# Altium schematic sheet Leptons_2.SchDoc
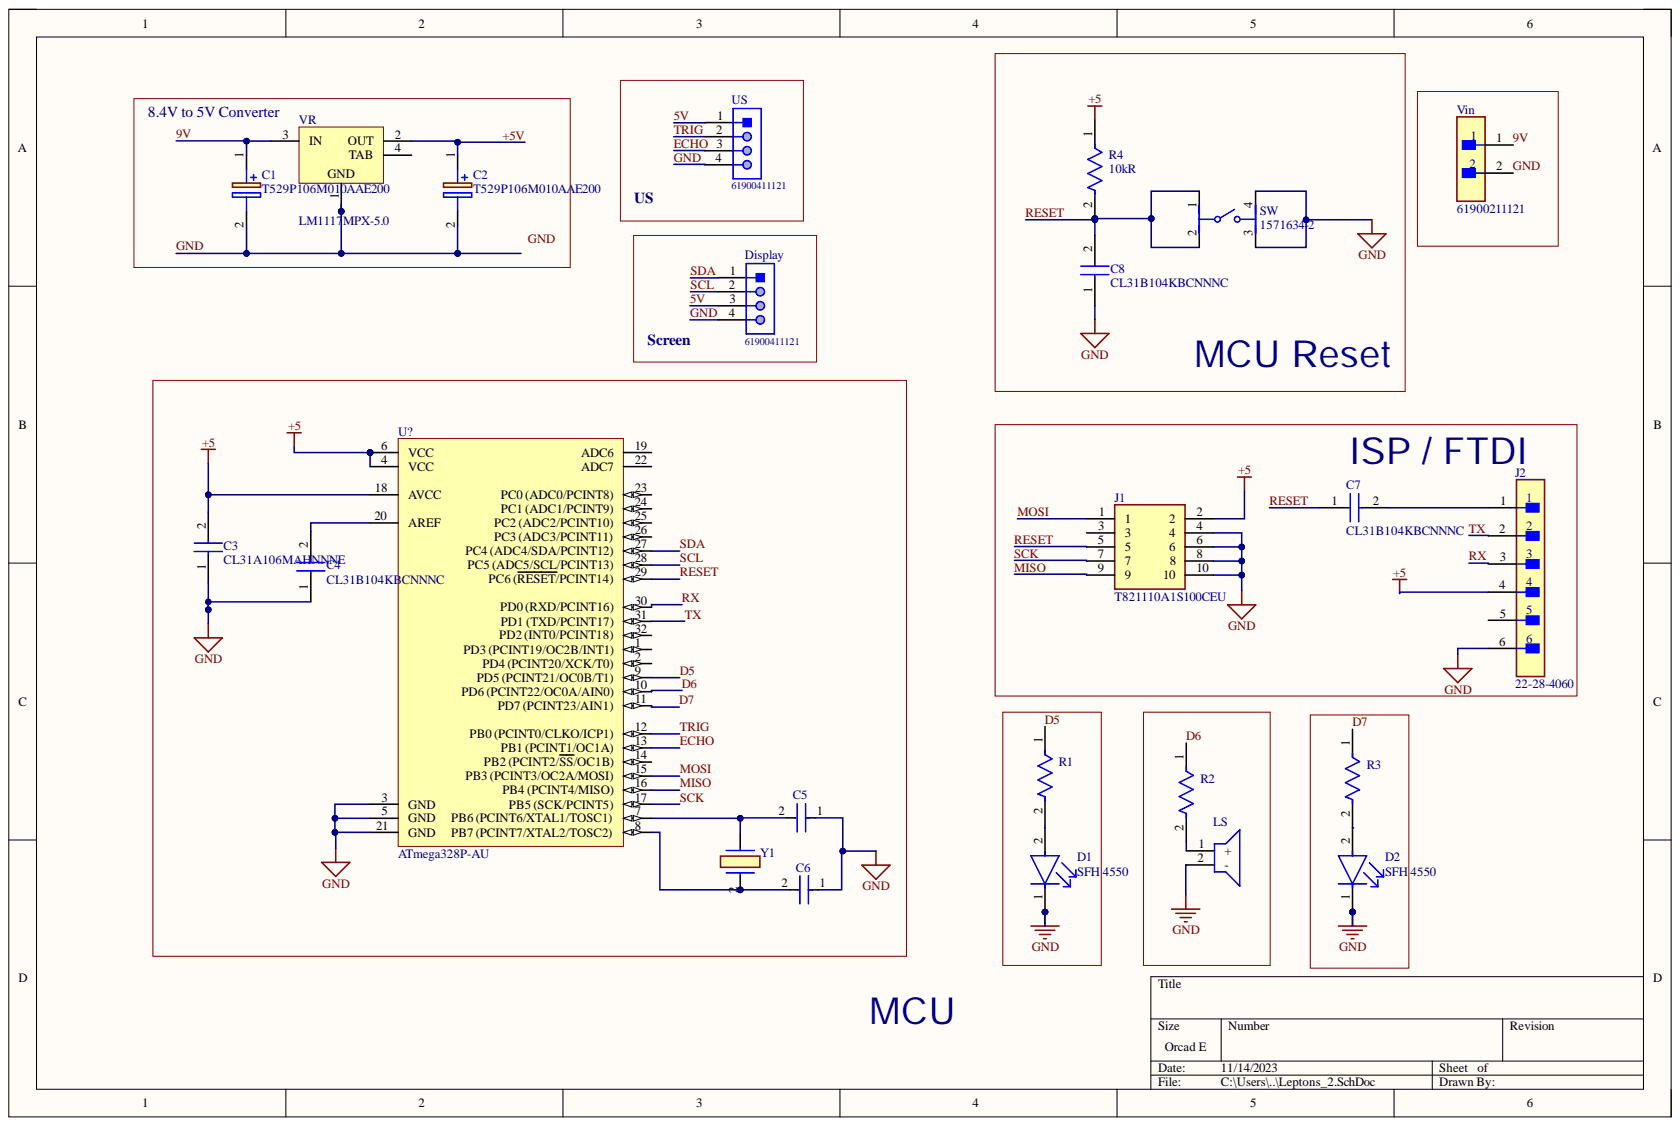
[diagram p0: Leptons_2.SchDoc]

## Parts
C1  T529P106M010AAE200  [T529P]
C2  T529P106M010AAE200  [T529P]
C3  CL31A106MAHNNNE  [CAPC3216X180N]
C4  CL31B104KBCNNNC  [CAPC3216X100N]
C5  CL31C220JBCNNNC  [FP-CL31-IPC_A]  (value not drawn)
C6  CL31C220JBCNNNC  [FP-CL31-IPC_A]  (value not drawn)
C7  CL31B104KBCNNNC  [CAPC3216X100N]
C8  CL31B104KBCNNNC  [CAPC3216X100N]
D1  SFH 4550  [FP-SFH_4550-MFG]
D2  SFH 4550  [FP-SFH_4550-MFG]
Display  61900411121  [61900411121]
J1  T821110A1S100CEU  [SHDR10W64P254X254_2X5_2032X895X915P]
J2  22-28-4060  [FP-22-28-4060-MFG]
LS  CMI-1295-0585T  [CMI12950585T]  (value not drawn)
R1  RMCF1206FT10K0  [FP-RMCF1206-IPC_B]  (value not drawn)
R2  RMCF1206FT10K0  [FP-RMCF1206-IPC_B]  (value not drawn)
R3  RMCF1206FT10K0  [FP-RMCF1206-IPC_B]  (value not drawn)
R4  Resistance: 10kR  [FP-RMCF1206-IPC_B]  (hidden comment: RMCF1206FT10K0)
SW  1571634-2  [FP-1571634-2-MFG]
U?  ATmega328P-AU  [32A_M]
US  61900411121  [61900411121]
Vin  61900211121  [FP-61900211121-MFG]
VR  LM1117MPX-5.0  [DCY0004A_L]
Y1  ECS-160-20-3X-TR  [ECS160203XTR]  (value not drawn)

## Nets
5V: C2.1 C3.2 Display.3 J1.2 J2.4 R4.1 U?.4(VCC) U?.6(VCC) U?.18(AVCC) US.1 VR.2(OUT)  [also labelled +5V]
9V: C1.1 Vin.1 VR.3(IN)
D5: R1.1 U?.9(PD5_(PCINT21/OC0B/T1))
D6: R2.1 U?.10(PD6_(PCINT22/OC0A/AIN0))
D7: R3.1 U?.11(PD7_(PCINT23/AIN1))
ECHO: U?.13(PB1_(PCINT1/OC1A)) US.3
GND: C1.2 C2.2 C3.1 C4.1 C5.1 C6.1 C8.1 D1.1 D2.1 Display.4 J1.4 J1.6 J1.8 J1.10 J2.6 LS.2 SW.3 SW.4 U?.3(GND) U?.5(GND) U?.21(GND) US.4 Vin.2 VR.1
MISO: J1.9 U?.16(PB4_(PCINT4/MISO))
MOSI: J1.1 U?.15(PB3_(PCINT3/OC2A/MOSI))
RESET: C7.1 C8.2 J1.5 R4.2 SW.1 SW.2 U?.29(PC6_(RESET/PCINT14))
RX: J2.3 U?.30(PD0_(RXD/PCINT16))
SCK: J1.7 U?.17(PB5_(SCK/PCINT5))
SCL: Display.2 U?.28(PC5_(ADC5/SCL/PCINT13))
SDA: Display.1 U?.27(PC4_(ADC4/SDA/PCINT12))
TRIG: U?.12(PB0_(PCINT0/CLKO/ICP1)) US.2
TX: J2.2 U?.31(PD1_(TXD/PCINT17))
NetC4_2: C4.2 U?.20(AREF)
NetC5_2: C5.2 U?.7 Y1.
NetC6_2: C6.2 U?.8 Y1.2
NetC7_2: C7.2 J2.1
NetD1_2: D1.2 R1.2
NetD2_2: D2.2 R3.2
NetLS_1: LS.1 R2.2

Not connected: J1 3; J2 5; U? 1(PD3_(PCINT19/OC2B/INT1)) 2(PD4_(PCINT20/XCK/T0)) 14(PB2_(PCINT2/SS/OC1B)) 19(ADC6) 22(ADC7) 23(PC0_(ADC0/PCINT8)) 24(PC1_(ADC1/PCINT9)) 25 26(PC3_(ADC3/PCINT11)) 32(PD2_(INT0/PCINT18)); VR 4(TAB)

## Library data of the parts
C1 C2 = T529P106M010AAE200: Capacitor Polarised; Manufacturer_Name: KEMET; Arrow Part Number: T529P106M010AAE200; Arrow Price/Stock: https://www.arrow.com/en/products/t529p106m010aae200/kemet-corporation?region=nac; Component Kind: Standard; Datasheet Link: https://content.kemet.com/datasheets/KEM_T2076_T52X-530.pdf; Height: 1mm; Manufacturer_Part_Number: T529P106M010AAE200; Mouser Part Number: 80-T529P106M10AAE200; Mouser Price/Stock: https://www.mouser.co.uk/ProductDetail/KEMET/T529P106M010AAE200?qs=sSYV1F9c5cHTMSIZ4fl60g%3D%3D
C3 = CL31A106MAHNNNE: Capacitor; Manufacturer_Name: SAMSUNG; Arrow Part Number: CL31A106MAHNNNE; Arrow Price/Stock: https://www.arrow.com/en/products/cl31a106mahnnne/samsung-electro-mechanics?region=nac; Component Kind: Standard; Datasheet Link: https://www.arrow.com/en/products/cl31a106mahnnne/samsung-electro-mechanics; Height: 1.8mm; Manufacturer_Part_Number: CL31A106MAHNNNE; Mouser Part Number: 187-CL31A106MAHNNNE; Mouser Price/Stock: https://www.mouser.co.uk/ProductDetail/Samsung-Electro-Mechanics/CL31A106MAHNNNE?qs=9y2YoPkPyvYkOWbcUKSn%252BQ%3D%3D
C4 C7 C8 = CL31B104KBCNNNC: Capacitor; Manufacturer_Name: SAMSUNG; Arrow Part Number: CL31B104KBCNNNC; Arrow Price/Stock: https://www.arrow.com/en/products/cl31b104kbcnnnc/samsung-electro-mechanics?region=nac; Component Kind: Standard; Datasheet Link: https://datasheet.datasheetarchive.com/originals/distributors/Datasheets_SAMA/0fdb6a11e020a7299f4d0713dd2bd7ee.pdf; Height: 1mm; Manufacturer_Part_Number: CL31B104KBCNNNC; Mouser Part Number: 187-CL31B104KBCNNNC; Mouser Price/Stock: https://www.mouser.co.uk/ProductDetail/Samsung-Electro-Mechanics/CL31B104KBCNNNC?qs=349EhDEZ59oHABbyGq1iKA%3D%3D
C5 C6 = CMP-13271-001055-1: Cap Ceramic 22pF 50V C0G ±5% SMD 1206 +125°C Embossed T/R; Temperature Coefficient: 300ppm/°C; Capacitance: 22pF; Case Code (Imperial): 1206; Case Code (Metric): 3216; Case/Package: 1206; Component Kind: Standard; Depth: 1.6mm; Dielectric: C0G; Height: 0.85mm; Lead Free: Lead Free; Length: 3.2mm; Library Name: Altium Content Vault; Material: Ceramic; Max Operating Temperature: 125°C; Min Operating Temperature: -55°C; Mount: Surface Mount; Package Quantity: 1; Packaging: Tape and Reel; Radiation Hardening: No; Thickness: 0.039inch; Tolerance: 5%; Voltage: 50V; Voltage Rating: 50V; Voltage Rating (DC): 50V; Width: 0.063inch
D1 D2 = CMP-62016-000011-1: EMITTER IR 860NM 100MA RADIAL; Manufacturer: Osram Opto; Colour: Infrared; Forward Voltage: 1.5V; Led Orientation: Straight; Reverse Voltage: 5V; Viewing Angle: 6°; Automotive: No; Category: Diode; Component Kind: Standard; ComponentLink2Description: Datasheet; ComponentLink2URL: https://dammedia.osram.info/media/resource/hires/osram-dam-5580407/SFH%204550_EN.pdf; Device Class L1: Optoelectronics; Device Class L2: LEDs; Device Class L3: unset; Digikey Description: EMITTER IR 860NM 100MA RADIAL; Forward Current: 100mA; Lead Free: Yes; Library Name: Altium Content Vault; Manufacturer Part Number: SFH 4550; Mouser Description: Infrared Emitters - High Power Infrared 850 nm HALF ANGLE +/-3DEG; Octopart: https://octopart.com/sfh+4550-osram+opto-55410894; Package: SFH 4550; Peak Emmision Wavelength: 860nm; RoHS: TRUE; Temperature: -40°C to +100°C; Temperature Range High: +100°C; Temperature Range Low: -40°C
Display US = CMP-1502-00586-1: Male Locking Header WR-WTB, THT, Vertical, pitch 2.54 mm, 1 x 4 position; Manufacturer: Wurth Elektronik; Contact Resistance: 20mOhm; Pitch: 2.54mm; Position: 1x4; Working Voltage: 250VAC; Component Kind: Standard; Datasheet URL: https://katalog.we-online.de/em/datasheet/6190xx11121.pdf; Gender: Male; Library Name: Altium Content Vault; Manufacturer URL: http://www.we-online.de; Mounting Technology: THT; Operating Temperature: -40to105degC; Orientation: Vertical; Package Reference: 61900411121; Packaging: Bag; Part Number: 61900411121; Publisher: WurthElektronik
J1 = T821110A1S100CEU: Connector; Manufacturer_Name: Amphenol; Arrow Part Number: T821110A1S100CEU; Arrow Price/Stock: https://www.arrow.com/en/products/t821110a1s100ceu/amphenol-fci?region=europe; Component Kind: Standard; Datasheet Link: https://docs.rs-online.com/e09c/0900766b81359cba.pdf; Height: 9.15mm; Manufacturer_Part_Number: T821110A1S100CEU; Mouser Part Number: N/A; Mouser Price/Stock: https://www.mouser.co.uk/ProductDetail/Amphenol/T821110A1S100CEU?qs=3IN%252Br8p6BrNf%2FuKCWm44Bg%3D%3D
J2 = CMP-04776-000763-1: CONN HEADER VERT 6POS 2.54MM; Connector Type: Male Pin; Contact Resistance: 20mR; Current Rating: 4A; Gender: Male; Max Voltage Rating (AC): 250V; Max Voltage Rating (DC): 250.25kV; Number of Contacts: 6; Color: Black; Component Kind: Standard; Contact Material: Brass; Contact Mating Length: 0.24inch; Contact Plating: Tin; Contact Type: Male Pin; Depth: 2.49mm; Housing Color: Black; Housing Material: Thermoplastic; Length: 15.24mm; Library Name: Altium Content Vault; Max Operating Temperature: 75°C; Min Operating Temperature: 0°C; Mount: Through Hole; Number of Positions: 6; Number of Rows: 1; Orientation: Straight; Package Quantity: 1; Packaging: Bulk; Pitch: 2.54mm; RoHS Compliant: Yes; Series: KK® 42375; Termination: Solder; Voltage Rating: 250V
LS = CMI-1295-0585T: Loudspeaker or Buzzer; Manufacturer_Name: CUI Devices; Arrow Part Number: CMI-1295-0585T; Arrow Price/Stock: https://www.arrow.com/en/products/cmi-1295-0585t/cui-devices?region=nac; Component Kind: Standard; Datasheet Link: https://www.cuidevices.com/product/resource/pdf/cmi-1295-0585t.pdf; Height: 9.7mm; Manufacturer_Part_Number: CMI-1295-0585T; Mouser Part Number: 490-CMI-1295-0585T; Mouser Price/Stock: https://www.mouser.co.uk/ProductDetail/CUI-Devices/CMI-1295-0585T?qs=P1JMDcb91o6b8IYPugSq6A%3D%3D
R1-R3 = CMP-26527-000151-1: 10kΩ ±1% 0.25W 1206 Thick Film Chip Resistor AEC-Q200 compliant RMCF1206FT10K0; Max Power Dissipation: 250mW; Resistance: 10kR; Temperature Coefficient: 100ppm/°C; Case Code (Imperial): 1206; Case Code (Metric): 3216; Case/Package: 1206; Component Kind: Standard; Construction: Rectangular; Contact Plating: Tin; Depth: 1.6mm; Features: Automotive AEC-Q200; Height: 0.028inch; Lead Free: Lead Free; Length: 3.2mm; Library Name: Altium Content Vault; Max Operating Temperature: 155°C; Military Standard: Not; Min Operating Temperature: -55°C; Mount: Surface Mount; Number of Terminations: 2; Packaging: Tape and Reel; Power Rating: 250mW; Radiation Hardening: No; Resistor Type: Thick Film; RoHS Compliant: Yes; Series: RMCF; Size Code: 1206; Tolerance: 1%; Width: 0.063inch
R4 = CMP-26527-000151-1: 10kΩ ±1% 0.25W 1206 Thick Film Chip Resistor AEC-Q200 compliant RMCF1206FT10K0; Max Power Dissipation: 250mW; Temperature Coefficient: 100ppm/°C; Case Code (Imperial): 1206; Case Code (Metric): 3216; Case/Package: 1206; Component Kind: Standard; Construction: Rectangular; Contact Plating: Tin; Depth: 1.6mm; Features: Automotive AEC-Q200; Height: 0.028inch; Lead Free: Lead Free; Length: 3.2mm; Library Name: Altium Content Vault; Max Operating Temperature: 155°C; Military Standard: Not; Min Operating Temperature: -55°C; Mount: Surface Mount; Number of Terminations: 2; Packaging: Tape and Reel; Power Rating: 250mW; Radiation Hardening: No; Resistor Type: Thick Film; RoHS Compliant: Yes; Series: RMCF; Size Code: 1206; Tolerance: 1%; Width: 0.063inch
SW = CMP-03407-000008-1: SWITCH TACTILE SPST-NO 0.05A 24V; Actuator Color: Natural; Actuator Length: 0.094inch; Actuator Material: Rubber; Actuator Type: Standard; Contact Current Rating: 50mA; Illumination Type: Illuminated; Max Voltage Rating (DC): 12V; Mechanical Life: 5000000Cycles; Operating Force: 160gf; Switch Function: Off-Mom; Throw Configuration: SPST; Case/Package: SMD/SMT; Component Kind: Standard; Contact Material: Stainless Steel; Contact Plating: Silver; Current Rating: 50mA; Depth: 10mm; ELV: Compliant; Lead Free: Lead Free; Length: 6.2mm; Library Name: Altium Content Vault; Max Current Rating: 50mA; Max Operating Temperature: 85°C; Min Operating Temperature: -40°C; Mount: Surface Mount; Orientation: Straight; Package Quantity: 1000; Packaging: Tape and Reel; Radiation Hardening: No; RoHS Compliant: Yes; Termination: Gull Wing; Type: Switch; Voltage Rating (DC): 12V
U? = CMP-0095-00269-2: 8-bit AVR Microcontroller, 32KB Flash, 1KB EEPROM, 2KB SRAM, 32-pin TQFP, Industrial Grade (-40°C to 85°C); Code_JEDEC: MS-026-ABA; Component Kind: Standard; ComponentLink1Description: Manufacturer URL; ComponentLink1URL: http://www.atmel.com/; ComponentLink2Description: ATmega48A/48PA/88A/88PA/168A/168PA/328/328P Datasheet; ComponentLink2URL: http://www.atmel.com/dyn/resources/prod_documents/doc8271.pdf; ComponentLink3Description: ATmega48A/48PA/88A/88PA/168A/168PA/328/328P Summary; ComponentLink3URL: http://www.atmel.com/dyn/resources/prod_documents/8271S.pdf; DatasheetVersion: revD, May-2011; Library Name: Altium Content Vault; PackageDescription: 32-Lead, 7x7x1.0 mm Body, 0.8mm Lead Pitch, Thin Profile Plastic Quad Flat Package (TQFP); PackageReference: 32A; PackageVersion: revC, Oct-2010; RevisionNote: DatasheetVersion & PackageVersion updated. URLs added.
Vin = CMP-1502-00584-2: CONN HEADER VERT 2POS 2.54MM; Current Rating: 3A; Gender: Male; Insulation Resistance: 1GR; Number of Contacts: 2; Voltage Rating: 250V; Color: Beige; Component Kind: Standard; Connector Type: Header; Contact Finish - Mating: TIN; Contact Gender: Male; Contact Material: Copper Alloy; Contact Mating Length: 0.295inch; Contact Plating: Tin; Contact Shape: Square; Contact Type: Male Pin; Fastening Type: Friction; Flammability Rating: UL94 V-0; Height: 14.2mm; Housing Material: Nylon; Insulation Color: Beige; Insulation Material: Nylon; Length: 5.8mm; Library Name: Altium Content Vault; Max Operating Temperature: 105°C; Min Operating Temperature: -40°C; Mount: Through Hole; Number of Positions: 2; Number of Rows: 1; Package Quantity: 1000; Packaging: Bulk; Pitch: 2.54mm; RoHS Compliant: Yes; Series: WR-WTB; Termination: Through Hole; Width: 5.12mm
VR = CMP-0062-01637-4: 800mA Low-Dropout Linear Regulator, 4-pin SOT-223; Manufacturer: Texas Instruments; CompType: LDO IC; High-Reliability: Y; PackageTopMarking: XYTT*N06A; PowerWise: N; Code_IPC: SOT223-4; Code_JEDEC: TO-261-AA; Component Kind: Standard; ComponentLink1Description: Datasheet URL; ComponentLink1URL: http://www.ti.com/general/docs/lit/getliterature.tsp?genericPartNumber=LM1117-N&fileType=pdf; Library Name: Altium Content Vault; PackageDescription: Molded SOT-223, 6.50 x 3.56 x 1.6mm Body, 4 Lead, 2.3mm Pitch; PackageReference: DCY0004A; PartNumber: LM1117MPX-5.0; PinCount: 4; RoHS: N
Y1 = ECS-160-20-3X-TR: Crystal or Oscillator; Manufacturer_Name: ECS; Arrow Part Number: ECS-160-20-3X-TR; Arrow Price/Stock: https://www.arrow.com/en/products/ecs-160-20-3x-tr/ecs-international?region=nac; Component Kind: Standard; Datasheet Link: https://www.arrow.com/en/products/ecs-160-20-3x-tr/ecs-international; Height: 2.3mm; Manufacturer_Part_Number: ECS-160-20-3X-TR; Mouser Part Number: 520-160-20-3XT; Mouser Price/Stock: https://www.mouser.co.uk/ProductDetail/ECS/ECS-160-20-3X-TR?qs=7Z%2FjUg96RbU1ElbRFXn8Rw%3D%3D
